# Altium schematic sheet Clock PLL.SchDoc("Clock PLL")
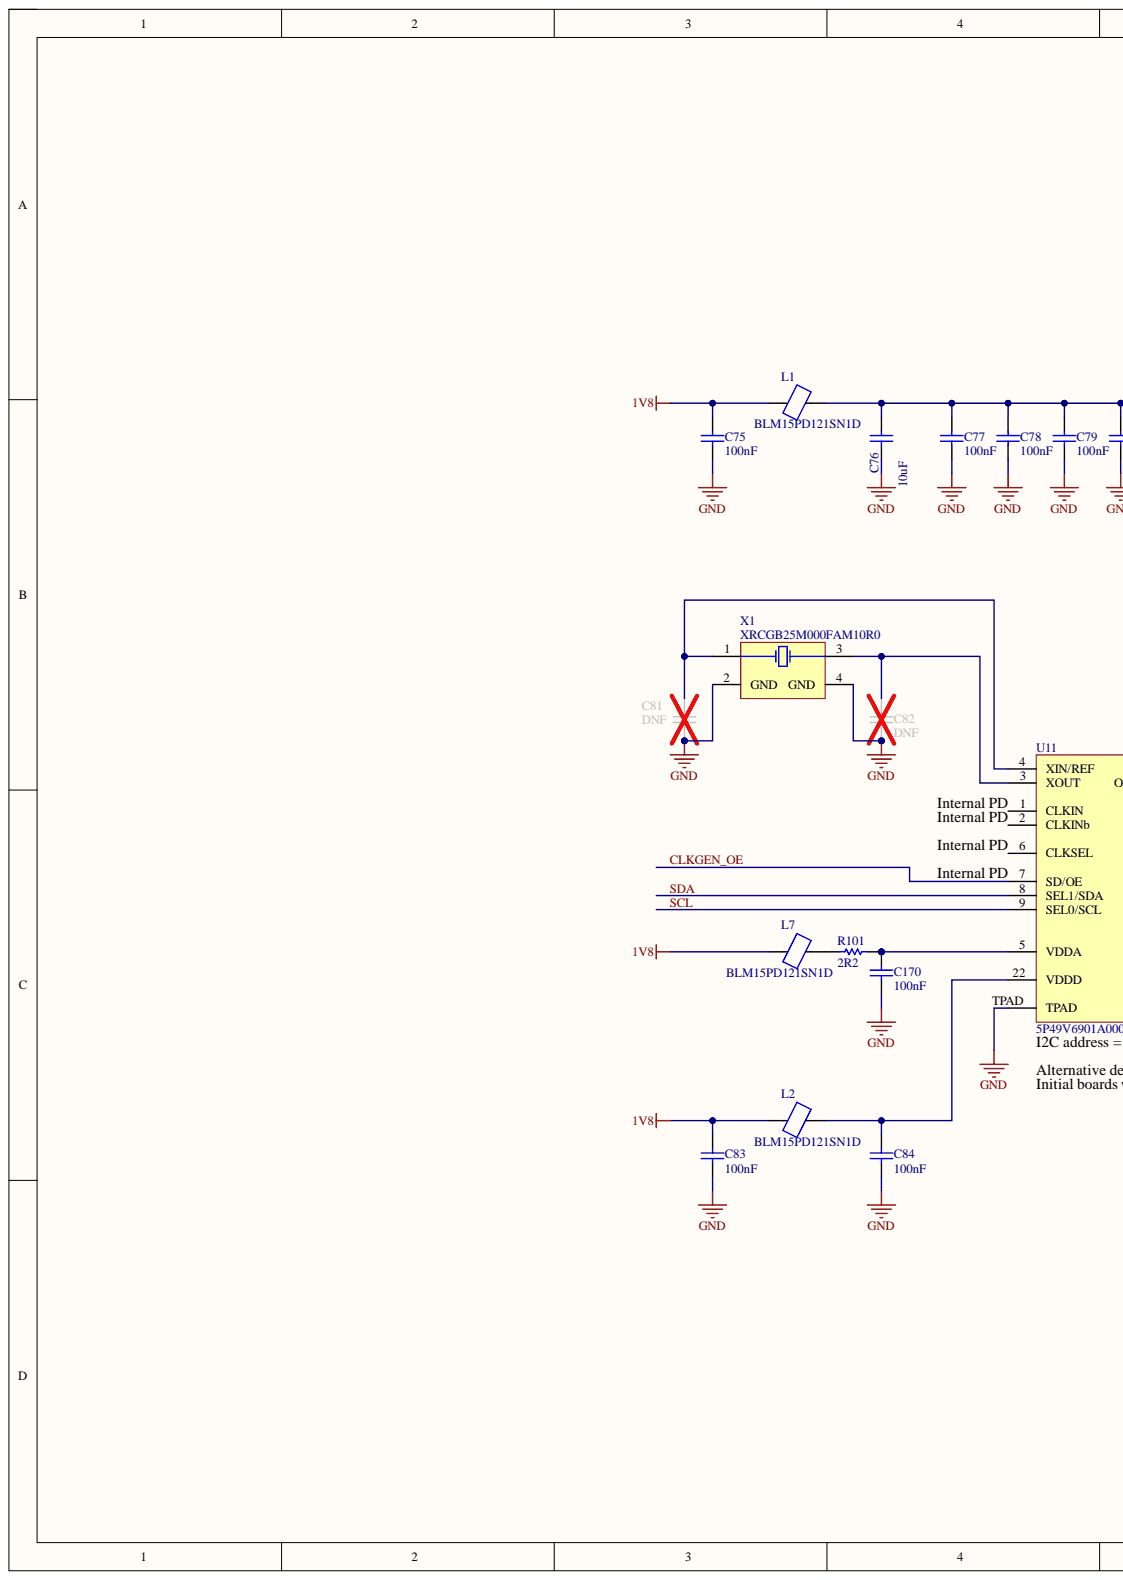
[diagram p0: Clock PLL.SchDoc, left]
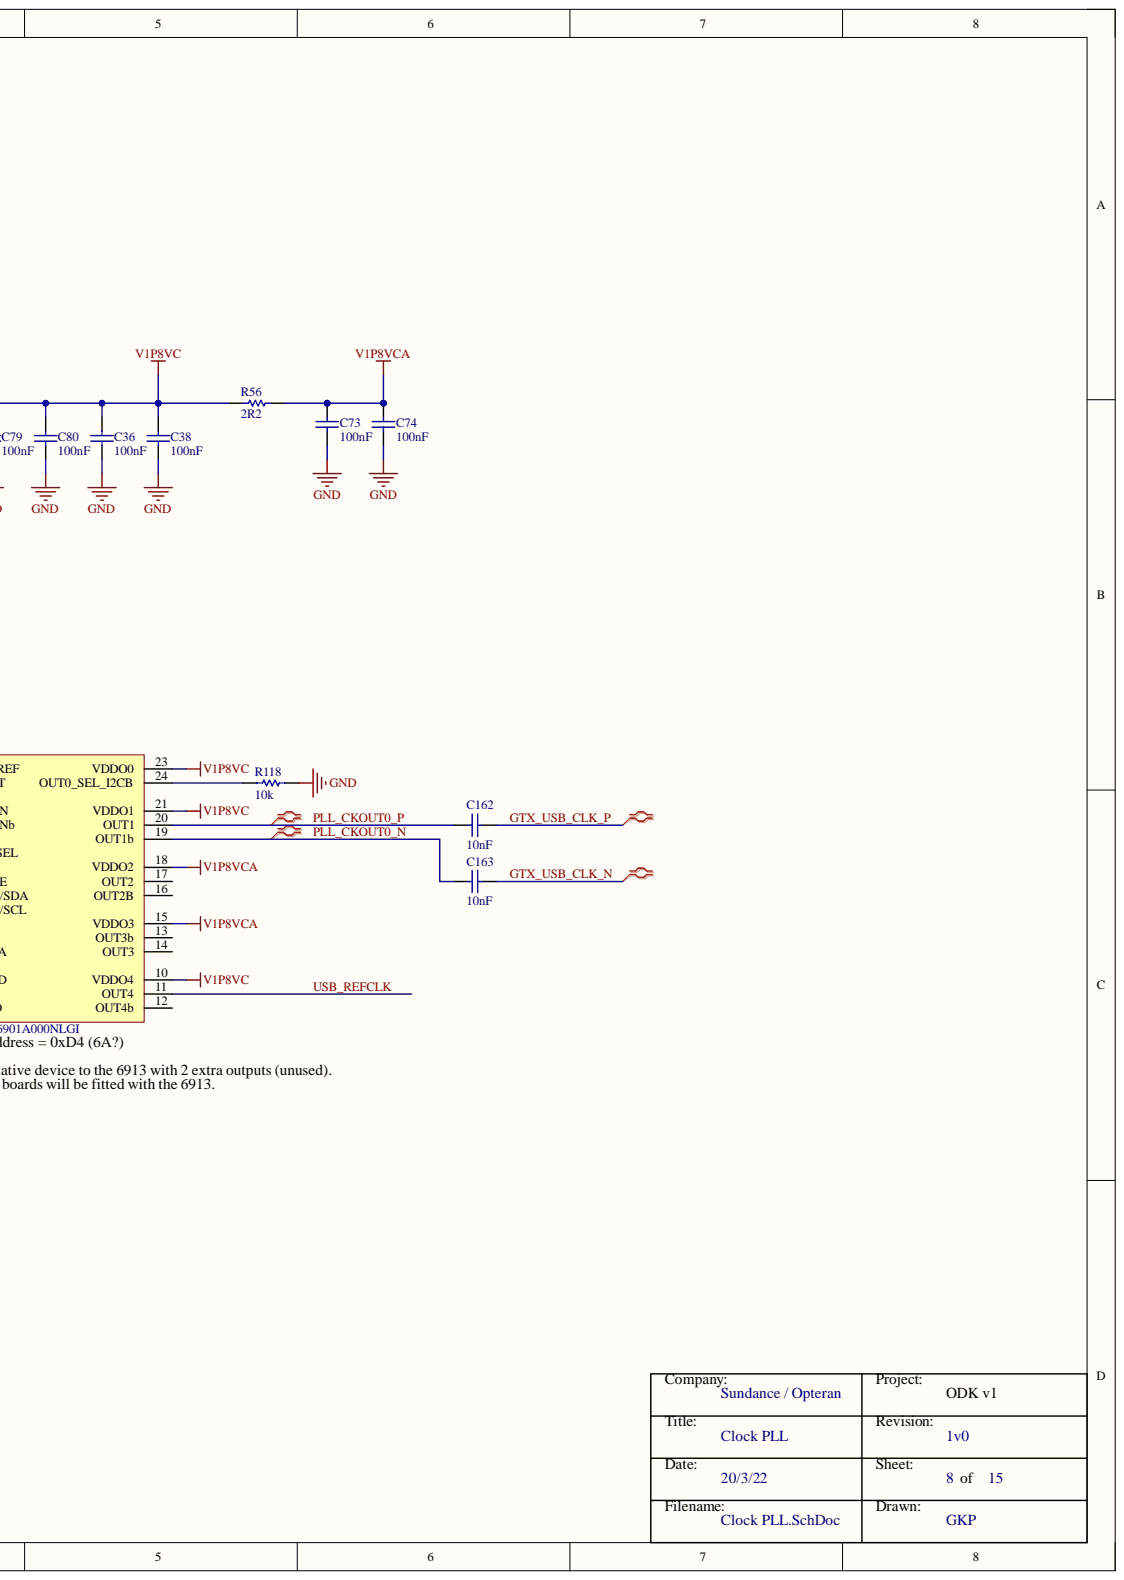
[diagram p1: Clock PLL.SchDoc, right]

## Parts
C36  100nF  [0201panERJ]
C38  100nF  [0201panERJ]
C73  100nF  [0201panERJ]
C74  100nF  [0201panERJ]
C75  100nF  [0201panERJ]
C76  10uF  [CAP0402]
C77  100nF  [0201panERJ]
C78  100nF  [0201panERJ]
C79  100nF  [0201panERJ]
C80  100nF  [0201panERJ]
C81  DNF  [0201panERJ]  (no BOM)
C82  DNF  [0201panERJ]  (no BOM)
C83  100nF  [0201panERJ]
C84  100nF  [0201panERJ]
C162  10nF  [0201panERJ]
C163  10nF  [0201panERJ]
C170  100nF  [0201panERJ]
L1  BLM15PD121SN1D  [passive0402 - murata ferrite bead]
L2  BLM15PD121SN1D  [passive0402 - murata ferrite bead]
L7  BLM15PD121SN1D  [passive0402 - murata ferrite bead]
R56  2R2  [RES0201]
R101  2R2  [RES0201]
R118  10k  [RES0201]
U11  5P49V6901A000NLGI  [VFQFPN24 Renesas]
X1  XRCGB25M000FAM10R0  [XTAL 2x1.6mm 4SMD]

## Nets
1V8: C75.2 C83.2 L1.1 L2.1 L7.1
GND: C36.1 C38.1 C73.1 C74.1 C75.1 C76.1 C77.1 C78.1 C79.1 C80.1 C81.1 C82.1 C83.1 C84.1 C170.1 R118.1 U11.TPAD X1.2(GND) X1.4(GND)
V1P8VC: C36.2 C38.2 C76.2 C77.2 C78.2 C79.2 C80.2 L1.2 R56.2 U11.10(VDDO4) U11.21(VDDO1) U11.23(VDDO0)
V1P8VCA: C73.2 C74.2 R56.1 U11.15(VDDO3) U11.18(VDDO2)
CLKGEN_OE: U11.7(SD/OE)
GTX_USB_CLK_N: C163.1
GTX_USB_CLK_P: C162.1
PLL_CKOUT0_N: C163.2 U11.19(OUT1b)
PLL_CKOUT0_P: C162.2 U11.20(OUT1)
SCL: U11.9(SEL0/SCL)
SDA: U11.8(SEL1/SDA)
USB_REFCLK: U11.11(OUT4)
NetC81_2: C81.2 U11.4(XIN/REF) X1.1
NetC82_2: C82.2 U11.3(XOUT) X1.3
NetC84_2: C84.2 L2.2 U11.22(VDDD)
NetC170_2: C170.2 R101.1 U11.5(VDDA)
NetL7_2: L7.2 R101.2
NetR118_2: R118.2 U11.24(OUT0_SEL_I2CB)

Not connected: U11 1(CLKIN) 2(CLKINb) 6(CLKSEL) 12(OUT4b) 13(OUT3b) 14(OUT3) 16(OUT2B) 17(OUT2)

## Library data of the parts
C36 C38 C73-C75 C77-C84 C170 = Cap0201: 100nF 0201 6V3 X5R; Case-EIA: 0402; Library Name: Schematic Components.SchLib; Max Thickness: 0.6 mm; Technology: SMT
  C36 C38 C73-C75 C77-C80 C83 C84 C170: Component Kind: Standard
C76 = Cap0402: 10uF 0402 10V X5R; Case-EIA: 0402; Component Kind: Standard; Library Name: Schematic Components.SchLib; Max Thickness: 0.6 mm; Technology: SMT
C162 C163 = Cap0201: 10nF 0201 6V3 X5R; Case-EIA: 0402; Component Kind: Standard; Library Name: Schematic Components.SchLib; Max Thickness: 0.6 mm; Technology: SMT
L1 L2 L7 = BEAD_0402: 120 Ohms @ 100 MHz 1 Power Line Ferrite Bead 0402 (1005 Metric) 1.3A 90mOhm; Manufacturer 1: Murata Electronics; Component Kind: Standard; Library Name: Schematic Components.SchLib; Manufacturer Part Number 1: BLM15PD121SN1D; Supplier 1: Digi-Key; Supplier Part Number 1: 490-5203-2-ND
R56 R101 = Res0201: 2R2 0201 1/16W 1%; Value: ?k; Component Kind: Standard; Library Name: Schematic Components.SchLib
R118 = Res0201: 10k 0201 1/16W 1%; Value: ?k; Component Kind: Standard; Library Name: Schematic Components.SchLib
U11 = PLL 5P49V6901A000NLGI: Clock Generator IC 350MHz 1 24-VFQFN Exposed Pad; Manufacturer 1: Renesas Electronics America Inc; Component Kind: Standard; Library Name: Schematic Components.SchLib; Manufacturer Part Number 1: 5P49V6901A000NLGI; Manufacturer Part Number 2: 5P49V6913A000NLGI; Supplier 1: Digi-Key; Supplier Part Number 1: 800-3135-ND
X1 = XTAL 2x1.6mm 4SMD: 25 MHz ±25ppm Crystal 8pF 80 Ohms 4-SMD, No Lead; Manufacturer 1: Murata Electronics; Component Kind: Standard; Library Name: Schematic Components.SchLib; Manufacturer Part Number 1: XRCGB25M000FAM10R0; Supplier 1: Digi-Key; Supplier Part Number 1: 490-18306-2-ND
